# Altium schematic sheet TVR_Rev2_Sensors_Actuators.SchDoc(TVR_Rev2_Sensors_Actuators)
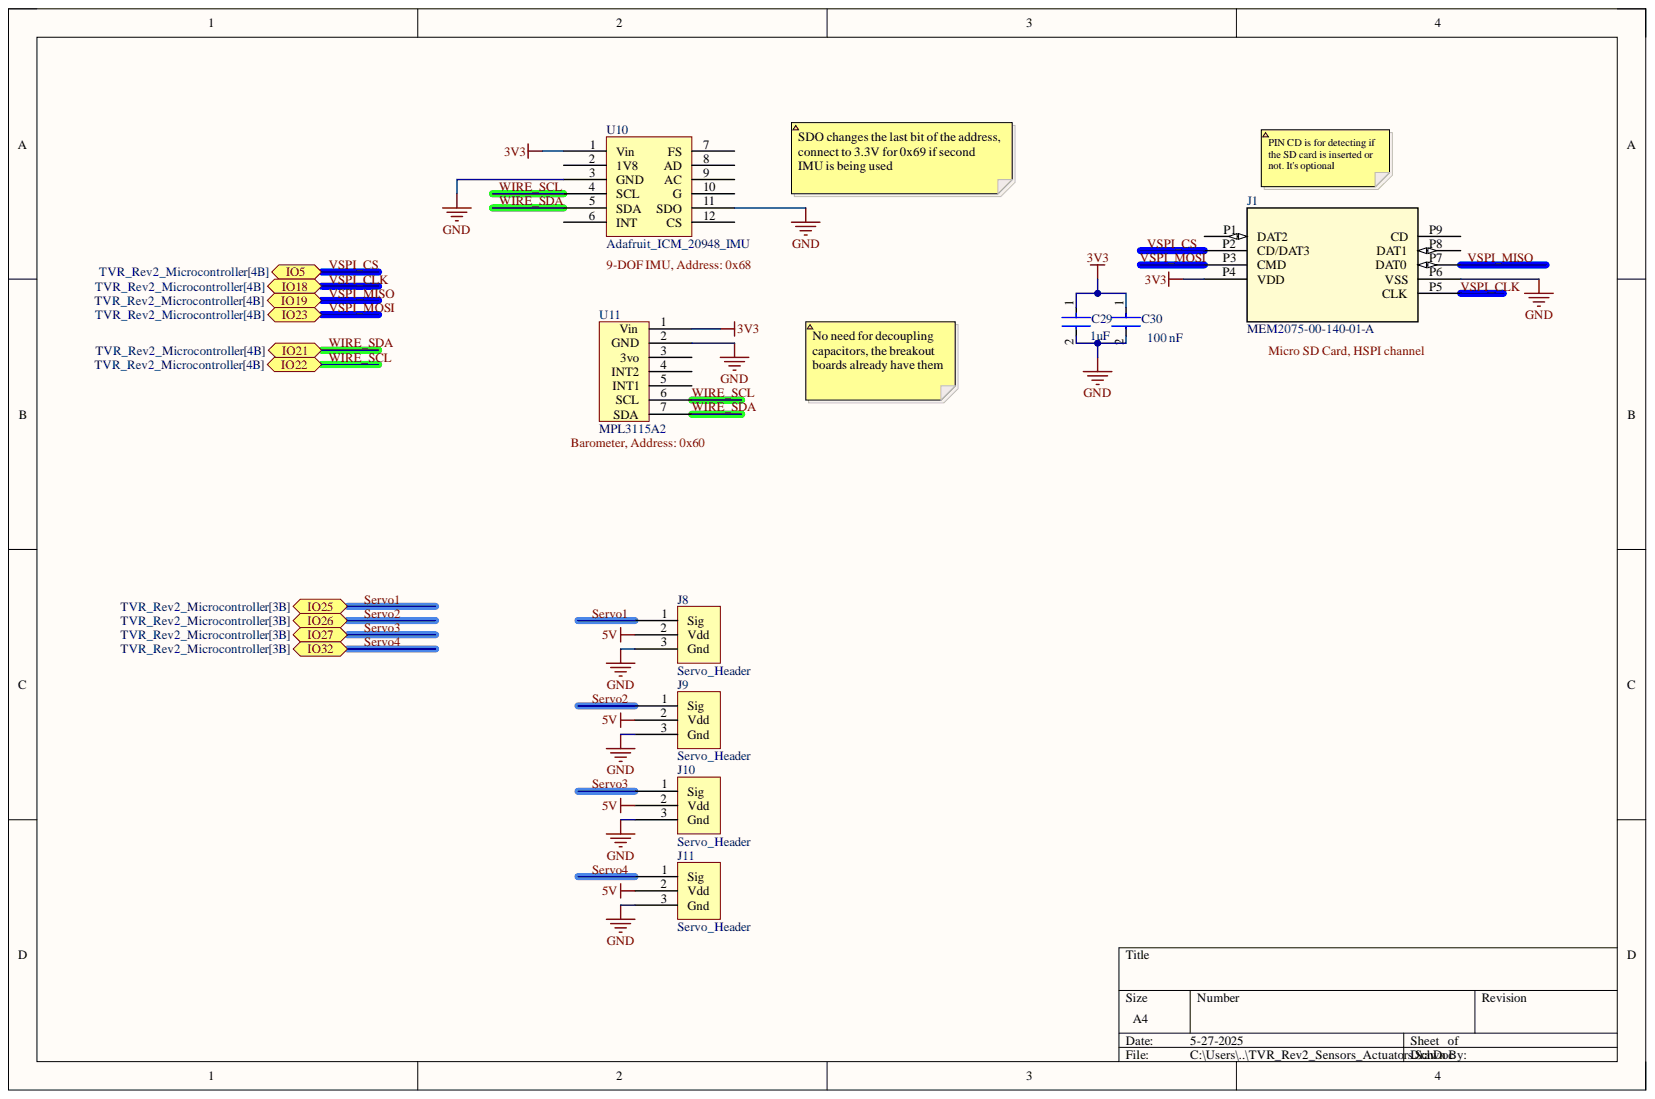
[diagram p0: TVR_Rev2_Sensors_Actuators.SchDoc]

## Parts
C29  Capacitance: 1uF  [FP-0805-L_2_01_0_2-W_1_25-MFG]  (hidden comment: 08055C105KAT2A)
C30  value 100 nF  [FP-CC0805-0_85-MFG]  (hidden comment: CC0805KRX7R9BB104)
J1  MEM2075-00-140-01-A  [MEM2075-00-140-01-A_GCT]
J8  Servo_Header  [ServoHeader]
J9  Servo_Header  [ServoHeader]
J10  Servo_Header  [ServoHeader]
J11  Servo_Header  [ServoHeader]
U10  Adafruit_ICM_20948_IMU  [Adafruit_ICM_20948_IMU]
U11  MPL3115A2  [MPL3115A2_DIP]

## Ports
IO5, IO18, IO19, IO21, IO22, IO23, IO25, IO26, IO27, IO32

## Nets
3V3: C29.1 C30.1 J1.P4(VDD) U10.1(Vin) U11.1(Vin)
5V: J8.2 J9.2 J10.2 J11.2
GND: C29.2 C30.2 J1.P6(VSS) J8.3 J9.3 J10.3 J11.3 U10.3(GND) U10.11(SDO) U11.2(GND)
Servo1: J8.1 ; port IO25
Servo2: J9.1 ; port IO26
Servo3: J10.1 ; port IO27
Servo4: J11.1 ; port IO32
VSPI_CLK: J1.P5(CLK) ; port IO18
VSPI_CS: J1.P2(CD/DAT3) ; port IO5
VSPI_MISO: J1.P7(DAT0) ; port IO19
VSPI_MOSI: J1.P3(CMD) ; port IO23
WIRE_SCL: U10.4(SCL) U11.6(SCL) ; port IO22
WIRE_SDA: U10.5(SDA) U11.7(SDA) ; port IO21

Not connected: J1 P1(DAT2) P8(DAT1) P9(CD); U10 2(1V8) 6(INT) 7(FS) 8(AD) 9(AC) 10(G) 12(CS); U11 3(3vo) 4(INT2) 5(INT1)

## Library data of the parts
C29 = CMP-2007-04696-2: General Purpose Ceramic Capacitor, 0805, 1uF, 10%, X7R, 15%, 50V; Dissipation Factor: 2.5%; Case Code (Imperial): 0805; Case Code (Metric): 2012; Case/Package: 0805; Component Kind: Standard; Contact Plating: Tin; Depth: 1.25mm; Dielectric: X7R; Lead Free: Lead Free; Length: 2.01mm; Library Name: Altium Content Vault; Max Operating Temperature: 125°C; Min Operating Temperature: -55°C; Mount: Surface Mount; Package Quantity: 1; Packaging: Tape and Reel; Radiation Hardening: No; REACH SVHC: No SVHC; RoHS Compliant: Yes; Series: -; Termination: SMD/SMT; Thickness: 0.037inch; Tolerance: 10%; Voltage: 50V; Voltage Rating: 50V; Voltage Rating (DC): 50V; Width: 0.049inch
C30 = CMP-2100-03606-3: CAP CER 0.1UF 50V X7R 0805; Manufacturer: Yageo; Material: Ceramic; Tolerance: 0.1; Automotive: No; Capacitance: 100 nF; Category: Cap; Component Kind: Standard; ComponentLink2Description: Datasheet; ComponentLink2URL: http://www.yageo.com/documents/recent/UPY-GPHC_Y5V_6.3V-to-50V_8.pdf; Device Class L1: Passive Components; Device Class L2: Capacitors; Device Class L3: Ceramic Capacitors; Digikey Description: CAP CER 0.1UF 50V X7R 0805; Footprint Url: http://www.yageo.com/exep/pages/download/literatures/UPY-C_GEN_24.pdf; Height: 0.95mm; Ipc Land Pattern Name: CAPC200125X85; Lead Free: Yes; Library Name: Altium Content Vault; Manufacturer Part Number: CC0805KRX7R9BB104; Mouser Description: Multilayer Ceramic Capacitors MLCC - SMD/SMT 100nF 50V X7R 10%; Octopart: https://octopart.com/cc0805krx7r9bb104-yageo-192993; Package: CC0805-0.85; RoHS: TRUE; Temperature: -30°C to +85°C; Temperature Characteristic: X7R; Temperature Range High: +85°C; Temperature Range Low: -30°C; Voltage Rating: 50 V
J1 = MEM2075-00-140-01-A: No Description Available; Mfr_Name: Global Connector Technology; Component Kind: Standard; RefDes: RefDes; Type: Type; Library Name: TVR.SchLib
J8-J11 = Servo_Header: Component Kind: Standard; Library Name: Schlib2.SchLib
U10 = Adafruit_ICM_20948_IMU: Component Kind: Standard; Library Name: Schlib2.SchLib
U11 = MPL3115A2: Component Kind: Standard; Library Name: Schlib2.SchLib
